# Altium schematic sheet p15_MOTOR.SchDoc
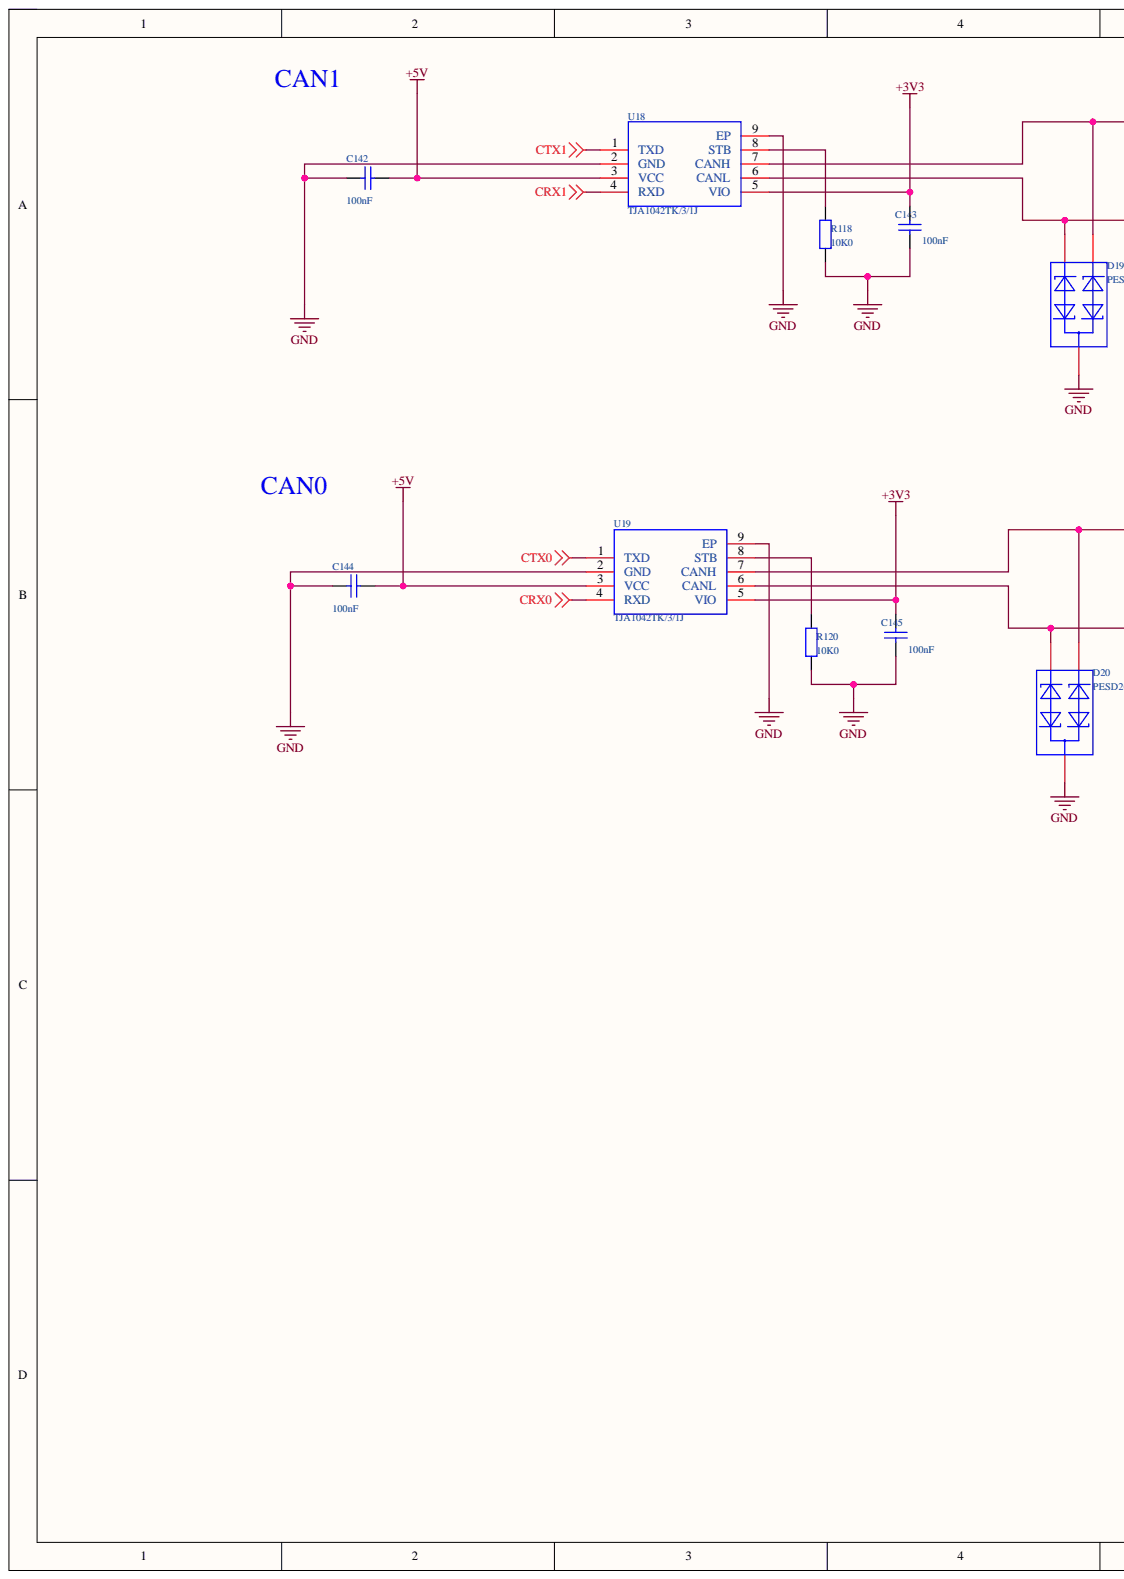
[diagram p0: p15_MOTOR.SchDoc, left]
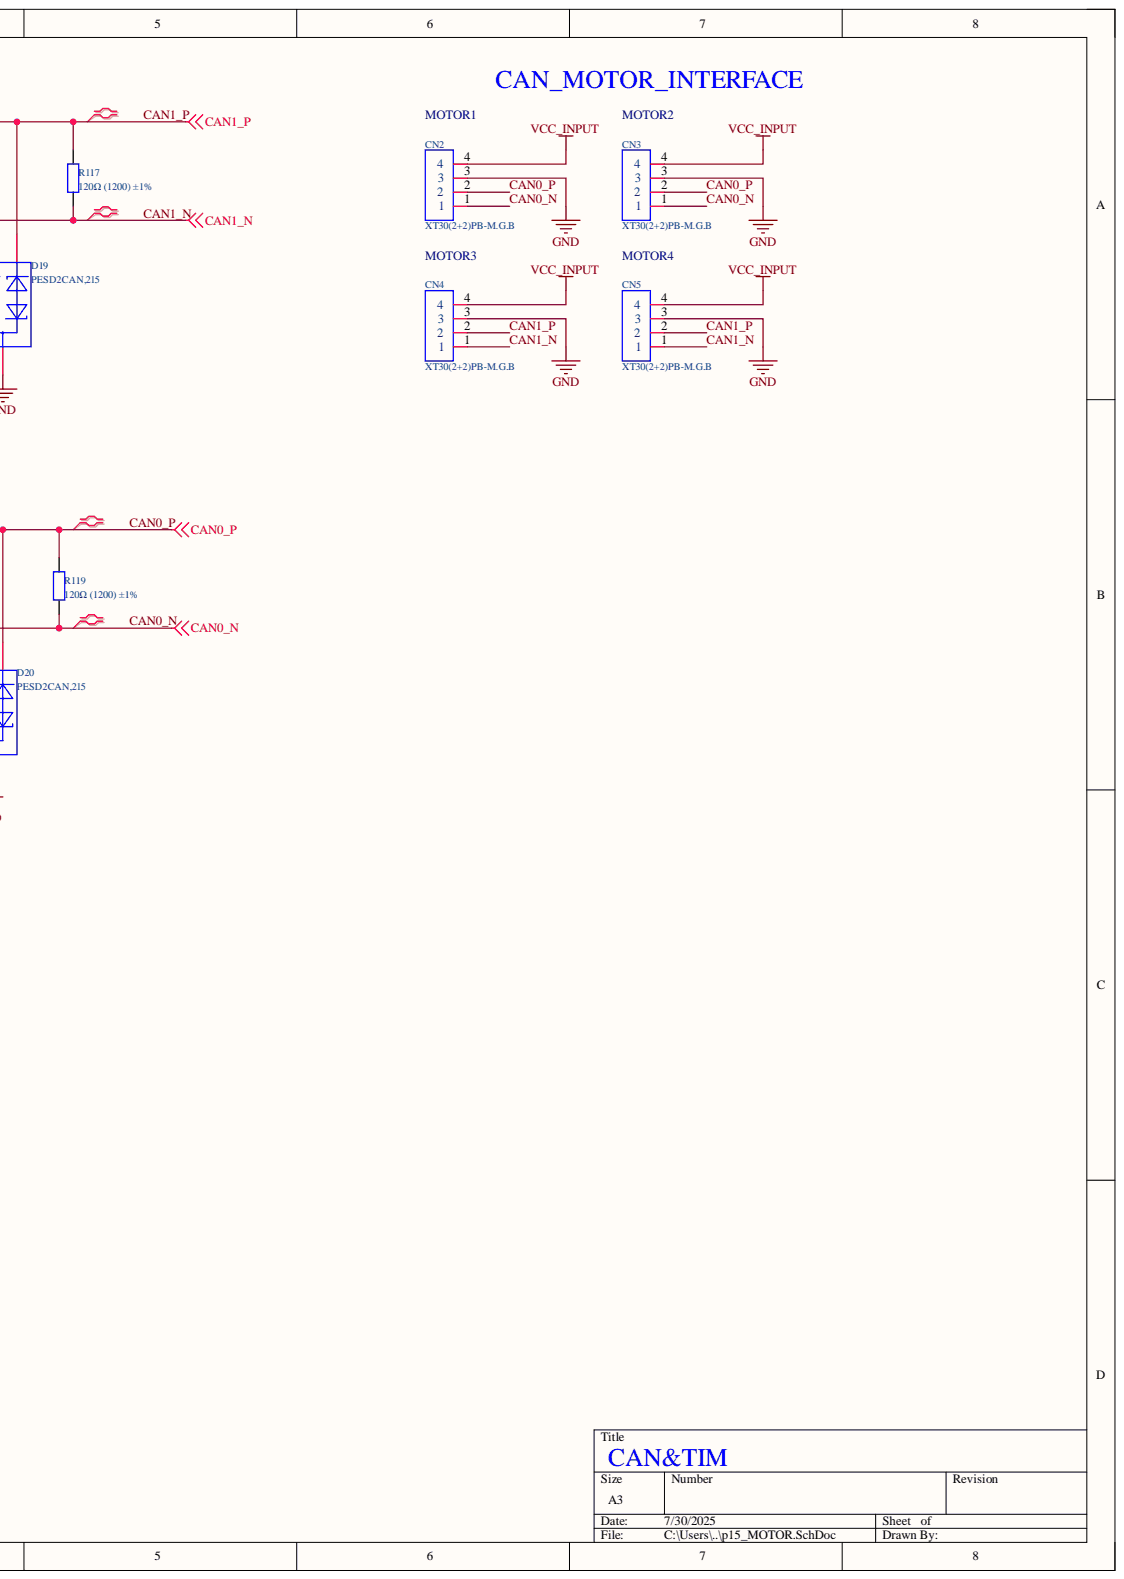
[diagram p1: p15_MOTOR.SchDoc, right]

## Parts
C142  Capacitance: 100nF  [C0402]  (hidden comment: 100 nf (0.1uF) 16V)
C143  Capacitance: 100nF  [C0402]  (hidden comment: 100 nf (0.1uF) 16V)
C144  Capacitance: 100nF  [C0402]  (hidden comment: 100 nf (0.1uF) 16V)
C145  Capacitance: 100nF  [C0402]  (hidden comment: 100 nf (0.1uF) 16V)
CN2  XT30(2+2)PB-M.G.B  [CONN-TH_XT30PB-M]
CN3  XT30(2+2)PB-M.G.B  [CONN-TH_XT30PB-M]
CN4  XT30(2+2)PB-M.G.B  [CONN-TH_XT30PB-M]
CN5  XT30(2+2)PB-M.G.B  [CONN-TH_XT30PB-M]
D19  PESD2CAN,215  [SOT-23-3_L2.9-W1.3-P1.90-LS2.4-BR]
D20  PESD2CAN,215  [SOT-23-3_L2.9-W1.3-P1.90-LS2.4-BR]
R117  120Ω (1200) ±1%  [R0402]
R118  10K0  [R0402]
R119  120Ω (1200) ±1%  [R0402]
R120  10K0  [R0402]
U18  TJA1042TK/3/1J  [HVSON-8_L3.0-W3.0-P0.65-BL-EP]
U19  TJA1042TK/3/1J  [HVSON-8_L3.0-W3.0-P0.65-BL-EP]

## Nets
+3V3: C143.2 C145.2 U18.5(VIO) U19.5(VIO)
+5V: C142.2 C144.2 U18.3(VCC) U19.3(VCC)
CRX0: U19.4(RXD)
CRX1: U18.4(RXD)
CTX0: U19.1(TXD)
CTX1: U18.1(TXD)
GND: C142.1 C143.1 C144.1 C145.1 CN2.3 CN3.3 CN4.3 CN5.3 D19.3 D20.3 R118.2 R120.2 U18.2(GND) U18.9(EP) U19.2(GND) U19.9(EP)
VCC_INPUT: CN2.4 CN3.4 CN4.4 CN5.4
CAN0_N: CN2.1 CN3.1 D20.2 R119.2 U19.6(CANL)
CAN0_P: CN2.2 CN3.2 D20.1 R119.1 U19.7(CANH)
CAN1_N: CN4.1 CN5.1 D19.2 R117.2 U18.6(CANL)
CAN1_P: CN4.2 CN5.2 D19.1 R117.1 U18.7(CANH)
NetR118_1: R118.1 U18.8(STB)
NetR120_1: R120.1 U19.8(STB)

## Library data of the parts
C142-C145 = CAP-000030-01: Ceramic Chip Capacitor 0402 100 nf (0.1uF) 16V; Legacy Part Number: 151-10004022; Manufacturer: Yageo; Manufacturer Part Number 1: CC0402KRX7R8BB104; Dialectric: X7R; Notes: ANY EQUIVALENT; Tolerance: 10%; Component Kind: Standard; Library Name: ra8p1_renesas.SCHLIB; Manufacturer 1: Yageo; Manufacturer 2: Kemet; Manufacturer 3: AVX; Manufacturer Part Number 2: C0402C104K4RACTU; Manufacturer Part Number 3: 0402YC104KAT2A; Mfg: Yageo; Mfg P/N: CC0402KRX7R8BB104; Mfg Part Number: CC0402KRX7R8BB104; Package: 0402; PN: CC0402KRX7R8BB104; Supplier 1: Arrow Electronics; Supplier 2: Digi-Key; Supplier 3: Newark; Supplier 4: Mouser; Supplier Part Number 1: CC0402KRX7R8BB104; Supplier Part Number 2: 311-2077-1-ND; Supplier Part Number 3: 40AJ6370; Supplier Part Number 4: 603-CC402KRX7R8BB104; Voltage: 16V
CN2-CN5 = XT30(2+2)PB-M.G.B: XT30,2+2,PWR+DAT,直插公头; Manufacturer: AMASS(艾迈斯); Add into BOM: yes; Component Kind: Standard; Convert to PCB: yes; Datasheet: https://atta.szlcsc.com/upload/public/pdf/source/20240112/EA39AAAE8FEC10464B997A9AF1F68E09.pdf; Device: XT30(2+2)PB-M.G.B; JLCPCB Part Class: Extended Part; LCSC Part Name: XT30PB(2+2)功率数据混合插头公金黑PCB直插; Library Name: ra8p1_v0.1.SCHLIB; Manufacturer Part: XT30(2+2)PB-M.G.B; PCB3D: CONN-TH_XT30PB-M; Supplier: LCSC; Supplier Footprint: -; Supplier Part: C19268029; Symbol: XT30(2+2)PB-M.G.B
D19 D20 = PESD2CAN,215: Manufacturer: Nexperia(安世); Add into BOM: yes; Breakdown Voltage: 26.2V; Maximum Clamping Voltage: 41V; Number of Lines: 双路; Peak Pulse Current (Ipp)@10/1000us: 5A; Peak Pulse Power Dissipation (Ppp)@10/1000us: 230W; polarity: 双向; Reverse Stand-Off Voltage (Vrwm): 24V; Type: ESD; Component Kind: Standard; Convert to PCB: yes; Datasheet: https://atta.szlcsc.com/upload/public/pdf/source/20170505/1493951386298.pdf; Device: PESD2CAN,215; JLCPCB Part Class: Extended Part; LCSC Part Name: 双向ESD; Library Name: ra8p1_v0.1.SCHLIB; Manufacturer Part: PESD2CAN,215; Operating Temperature: -55℃~+150℃@(Ta); PCB3D: SOT-23-3P_L2.9-W1.3-H1.0-LS2.4-P0.95; Supplier: LCSC; Supplier Footprint: SOT-23; Supplier Part: C75176; Symbol: PESD2CAN,215
R117 R119 = 0402 120Ω (1200) 1%: 贴片电阻; Component Kind: Standard; ComponentLink1Description: 供应商链接; ComponentLink1URL: http://www.szlcsc.com/product/details_25822.html; Library Name: RES.SchLib; Package: 0402; Supplier: LC; SuppliersPartNumber: C25079
R118 R120 = RES-100099-01: Chip Resistor Thick Film 0402 10K0 ohm 1% 1/16W; Manufacturer: Yageo; Manufacturer Part Number 1: RC0402FR-0710KP; Notes: ANY EQUIVALENT; Component Kind: Standard; Library Name: ra8p1_renesas.SCHLIB; Manufacturer 1: Yageo; Manufacturer 2: Samsung Electro-Mechanics; Manufacturer 3: Stackpole Electronics Inc.; Manufacturer Part Number 2: RC1005F103CS; Manufacturer Part Number 3: RMCF0402FT10K0; Mfg: Yageo; Mfg Part Number: RC0402FR-0710KP; Package: 0402; PN: RC0402FR-0710KP; Power: 1/16W; Resistance: 10K0; Supplier 1: Digi-Key; Supplier 2: Digi-Key; Supplier 3: Digi-Key; Supplier Part Number 1: YAG1249CT-ND; Supplier Part Number 2: 1276-3431-1-ND; Supplier Part Number 3: RMCF0402FT10K0CT-ND; Tolerance: 1%
U18 U19 = TJA1042TK/3/1J: CAN收发器，DFN-8; Manufacturer: NXP(恩智浦); Add into BOM: yes; Data Rate: 5Mbps; Supply Voltage: 4.5V~5.5V; Component Kind: Standard; Convert to PCB: yes; Datasheet: https://www.nxp.com/docs/en/data-sheet/TJA1042.pdf; Device: TJA1042TK/3/1J; JLCPCB Part Class: Extended Part; LCSC Part Name: 5Mbps 4.5V~5.5V; Library Name: ra8p1_v0.1.SCHLIB; Manufacturer Part: TJA1042TK/3/1J; PCB3D: HVSON-8_L3.0-W3.0-H0.85-P0.65-BL-EP; Supplier: LCSC; Supplier Footprint: HVSON-8-EP(3x3); Supplier Part: C131480; Symbol: TJA1042TK/3/1J; Type: -
